# Altium schematic sheet 11-Ice40_FPGA.SchDoc(11-Ice40_FPGA)
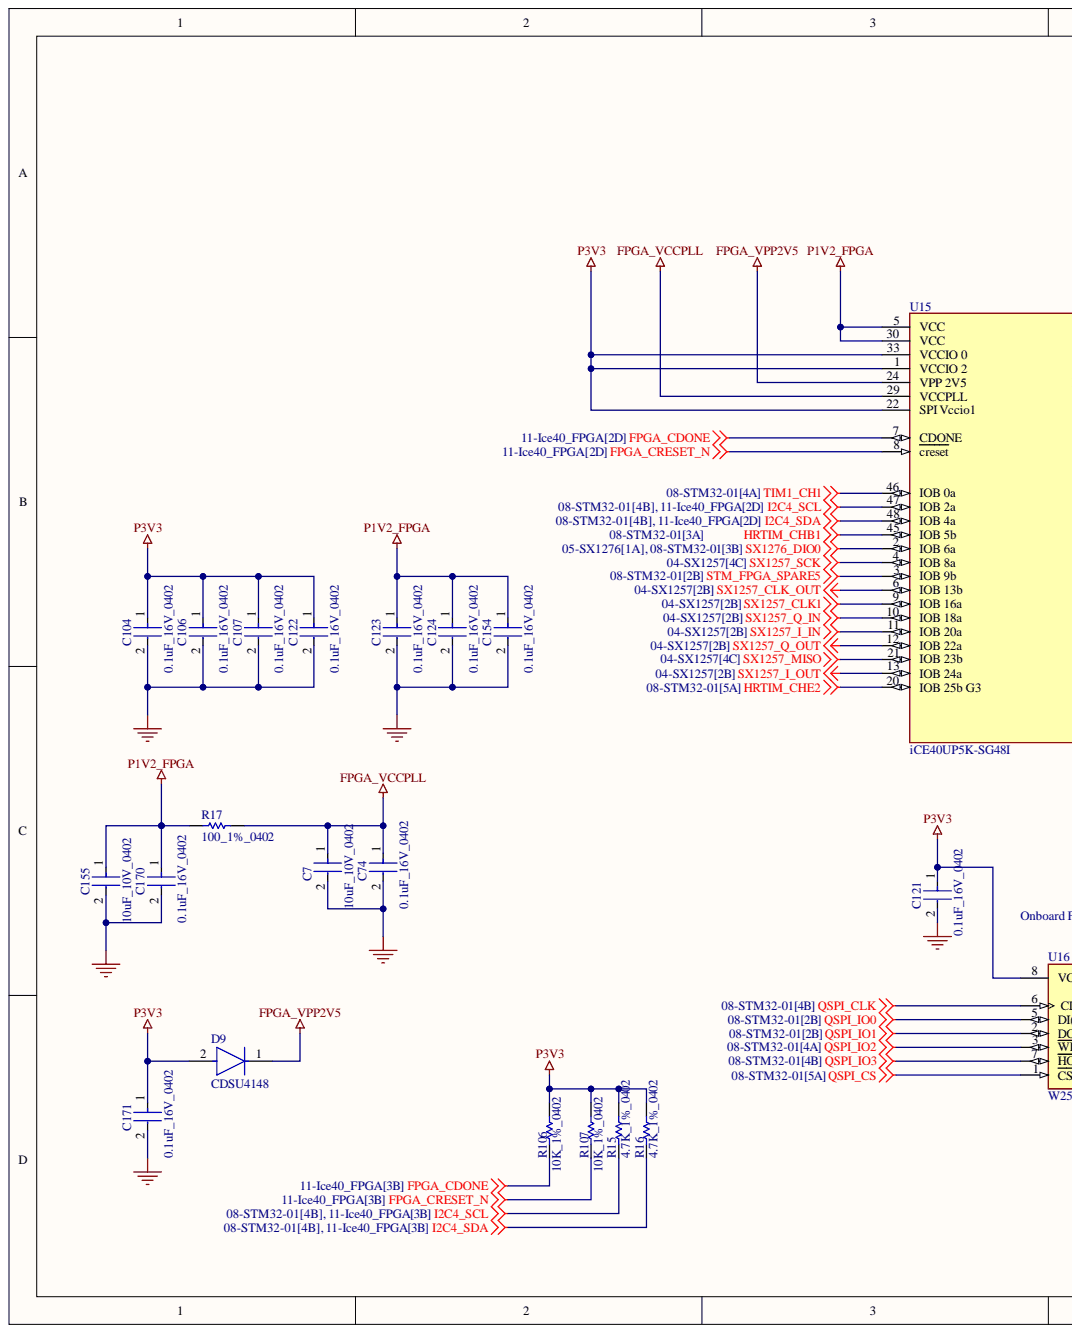
[diagram p0: 11-Ice40_FPGA.SchDoc, left]
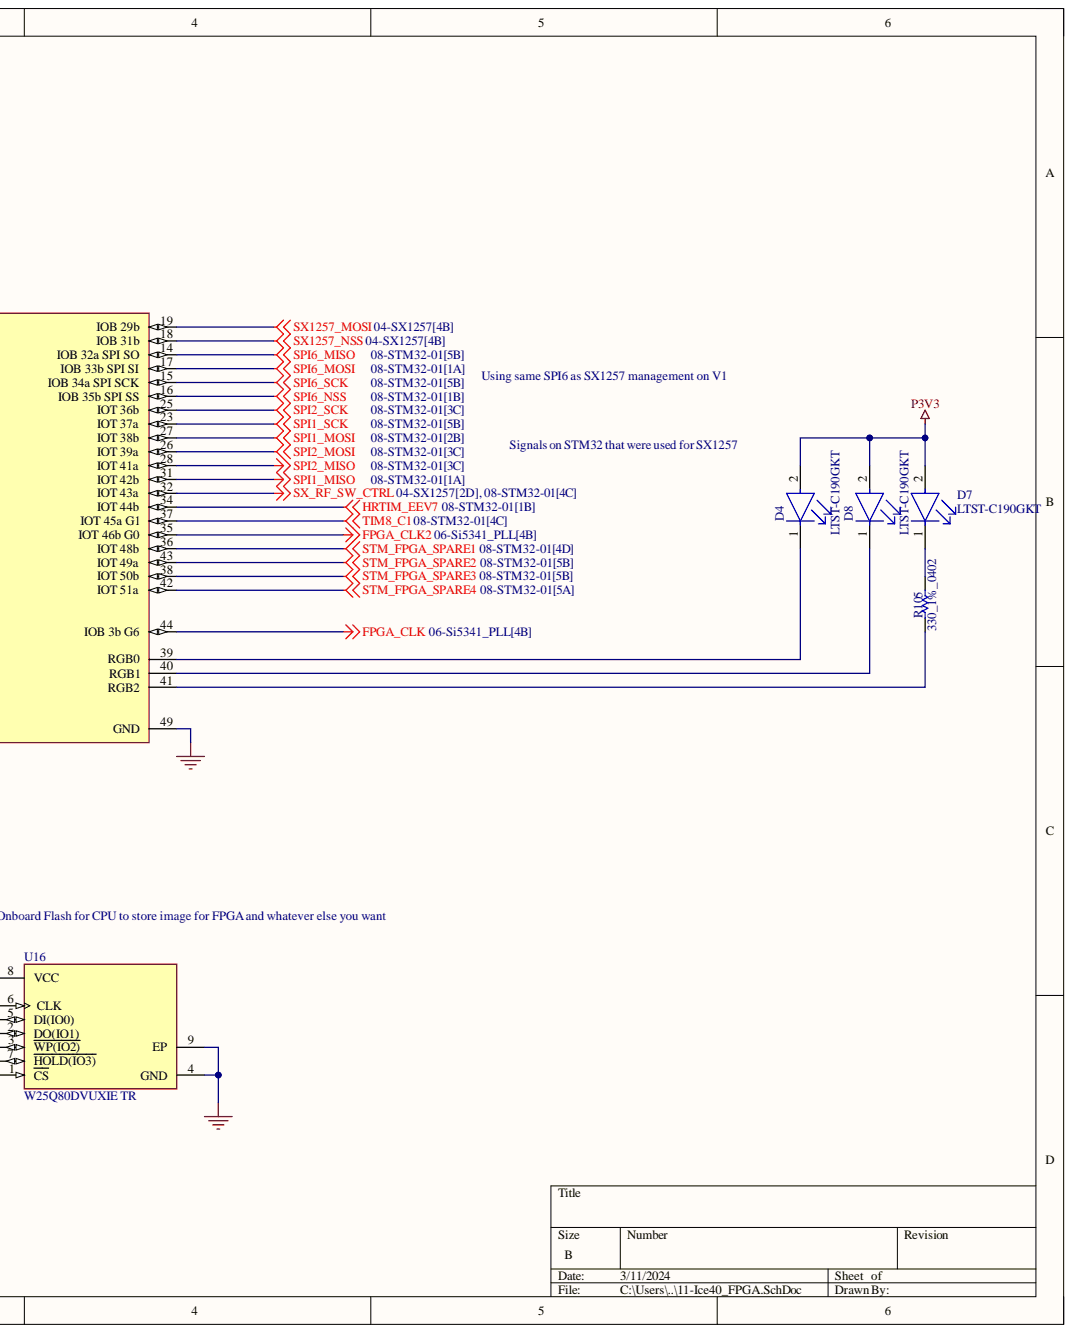
[diagram p1: 11-Ice40_FPGA.SchDoc, right]

## Parts
C7  10uF_10V_0402  [FP-CL05A106MP5NUNC-MFG]
C74  0.1uF_16V_0402  [FP-C0402-BB-MFG]
C104  0.1uF_16V_0402  [FP-C0402-BB-MFG]
C106  0.1uF_16V_0402  [FP-C0402-BB-MFG]
C107  0.1uF_16V_0402  [FP-C0402-BB-MFG]
C121  0.1uF_16V_0402  [FP-C0402-BB-MFG]
C122  0.1uF_16V_0402  [FP-C0402-BB-MFG]
C123  0.1uF_16V_0402  [FP-C0402-BB-MFG]
C124  0.1uF_16V_0402  [FP-C0402-BB-MFG]
C154  0.1uF_16V_0402  [FP-C0402-BB-MFG]
C155  10uF_10V_0402  [FP-CL05A106MP5NUNC-MFG]
C170  0.1uF_16V_0402  [FP-C0402-BB-MFG]
C171  0.1uF_16V_0402  [FP-C0402-BB-MFG]
D4  LTST-C190GKT  [FP-LTST-C190GKT-MFG]
D7  LTST-C190GKT  [FP-LTST-C190GKT-MFG]
D8  LTST-C190GKT  [FP-LTST-C190GKT-MFG]
D9  CDSU4148  [FP-0603-SOD-523F-MFG]
R15  4.7K_1%_0402  [RESC1005X40X25NL10T10]
R16  4.7K_1%_0402  [RESC1005X40X25NL10T10]
R17  100_1%_0402  [RESC1005X40X25LL05T05]
R105  330_1%_0402  [RESC1005X40X25NL10T10]
R106  10K_1%_0402  [RESC1005X40X25NL10T10]
R107  10K_1%_0402  [RESC1005X40X25NL10T10]
U15  iCE40UP5K-SG48I  [FP-QFN-48-IPC_C]
U16  W25Q80DVUXIE TR  [FP-UX-MFG]

## Nets
FPGA_CDONE: R106.1 U15.7(CDONE)
FPGA_CLK: U15.44(IOB_3b_G6)
FPGA_CLK2: U15.35(IOT_46b_G0)
FPGA_CRESET_N: R107.1 U15.8(creset)
FPGA_VCCPLL: C7.1 C74.1 R17.2 U15.29(VCCPLL)
FPGA_VPP2V5: D9.1 U15.24(VPP_2V5)
GND: C7.2 C74.2 C104.2 C106.2 C107.2 C121.2 C122.2 C123.2 C124.2 C154.2 C155.2 C170.2 C171.2 U15.49(GND) U16.4(GND) U16.9(EP)
HRTIM_CHB1: U15.45(IOB_5b)
HRTIM_CHE2: U15.20(IOB_25b_G3)
HRTIM_EEV7: U15.34(IOT_44b)
I2C4_SCL: R15.1 U15.47(IOB_2a)
I2C4_SDA: R16.1 U15.48(IOB_4a)
P1V2_FPGA: C123.1 C124.1 C154.1 C155.1 C170.1 R17.1 U15.5(VCC) U15.30(VCC)
P3V3: C104.1 C106.1 C107.1 C121.1 C122.1 C171.1 D4.2 D7.2 D8.2 D9.2 R15.2 R16.2 R106.2 R107.2 U15.1(VCCIO_2) U15.22(SPI_Vccio1) U15.33(VCCIO_0) U16.8(VCC)
QSPI_CLK: U16.6(CLK)
QSPI_CS: U16.1(CS)
QSPI_IO0: U16.5(DI(IO0))
QSPI_IO1: U16.2(DO(IO1))
QSPI_IO2: U16.3(WP(IO2))
QSPI_IO3: U16.7(HOLD(IO3))
SPI1_MISO: U15.31(IOT_42b)
SPI1_MOSI: U15.27(IOT_38b)
SPI1_SCK: U15.23(IOT_37a)
SPI2_MISO: U15.28(IOT_41a)
SPI2_MOSI: U15.26(IOT_39a)
SPI2_SCK: U15.25(IOT_36b)
SPI6_MISO: U15.14(IOB_32a_SPI_SO)
SPI6_MOSI: U15.17(IOB_33b_SPI_SI)
SPI6_NSS: U15.16(IOB_35b_SPI_SS)
SPI6_SCK: U15.15(IOB_34a_SPI_SCK)
STM_FPGA_SPARE1: U15.36(IOT_48b)
STM_FPGA_SPARE2: U15.43(IOT_49a)
STM_FPGA_SPARE3: U15.38(IOT_50b)
STM_FPGA_SPARE4: U15.42(IOT_51a)
STM_FPGA_SPARE5: U15.3(IOB_9b)
SX1257_CLK1: U15.9(IOB_16a)
SX1257_CLK_OUT: U15.6(IOB_13b)
SX1257_I_IN: U15.11(IOB_20a)
SX1257_I_OUT: U15.13(IOB_24a)
SX1257_MISO: U15.21(IOB_23b)
SX1257_MOSI: U15.19(IOB_29b)
SX1257_NSS: U15.18(IOB_31b)
SX1257_Q_IN: U15.10(IOB_18a)
SX1257_Q_OUT: U15.12(IOB_22a)
SX1257_SCK: U15.4(IOB_8a)
SX1276_DIO0: U15.2(IOB_6a)
SX_RF_SW_CTRL: U15.32(IOT_43a)
TIM1_CH1: U15.46(IOB_0a)
TIM8_C1: U15.37(IOT_45a_G1)
NetD4_1: D4.1 U15.39(RGB0)
NetD7_1: D7.1 R105.2
NetD8_1: D8.1 U15.40(RGB1)
NetR105_1: R105.1 U15.41(RGB2)

## Library data of the parts
C7 C155 = CMP-13271-000002-1: CAP CER 10UF 10V X5R 0402; Manufacturer: Samsung; Manufacturer Part Number: CL05A106MP5NUNC; Material: Ceramic; Temperature Coefficient: 15%; Tolerance: 20%; Automotive: No; Capacitance: 10uF; Category: Cap; Component Kind: Standard; ComponentLink2Description: Datasheet; ComponentLink2URL: http://www.samsungsem.com/kr/support/product-search/mlcc/__icsFiles/afieldfile/2019/05/10/19-CL05A106MP5NUNC.pdf; Device Class L1: Passive Components; Device Class L2: Capacitors; Device Class L3: Ceramic Capacitors; Digikey Description: CAP CER 10UF 10V X5R 0402; Height: 0.7mm; Ipc Land Pattern Name: CAPC10050X50; Lead Free: Yes; Library Name: Altium Content Vault; Octopart: https://octopart.com/cl05a106mp5nunc-samsung-22457395; Package: CL05A106MP5NUNC; RoHS: TRUE; Temperature: -55°C to +85°C; Temperature Characteristic: X5R; Temperature Range High: +85°C; Temperature Range Low: -55°C; Value: 10uF; Voltage Rating: 10V
C74 C104 C106 C107 C121-C124 C154 C170 C171 = CMP-1034-02068-3: CAP CER 0.1UF 16V 5% X7R 0402; Manufacturer: Kemet; Manufacturer Part Number: C0402C104J4RACTU; Capacitance: 0.10 μF; Material: Ceramic; Temperature Coefficient: 15%; Tolerance: 0.05; Value: 0.10 μF; Automotive: No; Category: Cap; Component Kind: Standard; ComponentLink2Description: Datasheet; ComponentLink2URL: https://content.kemet.com/datasheets/KEM_C1002_X7R_SMD.pdf; Device Class L1: Passive Components; Device Class L2: Capacitors; Device Class L3: Ceramic Capacitors; Digikey Description: CAP CER 0.1UF 16V 5% X7R 0402; Height: 0.55mm; Ipc Land Pattern Name: CAPC10050X50; Lead Free: Yes; Library Name: Altium Content Vault; Mouser Description: CAP CER 0.1UF 16V X7R 0402; Octopart: https://octopart.com/c0402c104j4ractu-kemet-12573893; Package: C0402-BB; RoHS: TRUE; Temperature: -55°C to +125°C; Temperature Characteristic: X7R; Temperature Range High: +125°C; Temperature Range Low: -55°C; Voltage Rating: 16 V
D4 D7 D8 = CMP-22017-000005-2: LED GREEN CLEAR CHIP SMD; Dominant Wavelength: 569nm; Forward Current: 30mA; Forward Voltage: 2.1V; Lens Color: Colorless; Luminous Intensity: 6mcd; Number of Elements: 1; Power Dissipation: 100mW; Reverse Voltage: 5V; Test Current: 10mA; Viewing Angle: 130°; Voltage: 2V; Wavelength: 565nm; Case Code (Imperial): 0603; Case Code (Metric): 1608; Case/Package: 0603; Color: Green; Component Kind: Standard; Depth: 0.8mm; Height: 0.8mm; Illumination Color: Green; Lead Free: Lead Free; Length: 1.6mm; Lens Style: Clear; Lens Transparency: Clear; Library Name: Altium Content Vault; Max Operating Temperature: 85°C; Min Operating Temperature: -55°C; Mount: Surface Mount; Number of LEDs: 1; Number of Pins: 2; Package Quantity: 1; Packaging: Tape and Reel; Power Rating: 100mW; Radiation Hardening: No; Width: 0.8mm
D9 = CMP-20228-000007-1: DIODE GEN PURP 75V 150MA 0603; Capacitance: 3pF; Current Rating: 100mA; Max Power Dissipation: 150mW; Max Reverse Leakage Current: 2.5uA; Max Surge Current: 4A; Polarity: Standard; Recovery Time: 4ns; Average Rectified Current: 150mA; Case/Package: SMD/SMT; Component Kind: Standard; Lead Free: Lead Free; Library Name: Altium Content Vault; Max Reverse Voltage (DC): 75V; Mount: Surface Mount; Output Current: 100mA; Package Quantity: 1; Packaging: Tape and Reel; Reverse Recovery Time: 4ns; Voltage Rating (DC): 75V
R15 R16 = CMP-2002-05217-1: Manufacturer: Bourns; Part Number: CR0402-FX-4701GLF; Component Kind: Standard; Library Name: Altium Content Vault
R17 = CMP-2002-00605-3: Chip Resistor, 100 Ohm, +/- 1%, 100 mW, -55 to 155 degC, 0402 (1005 Metric), RoHS, Tape and Reel; Manufacturer: Basic Passives; PartNumber: ERJ-2RKF1000X; Case\/Package: 0402; Power Rating: 100 mW; Resistance: 100 Ohm; Voltage Rating: 50 V; Case Code (Imperial): 0402; Case Code (Metric): 1005; Component Kind: Standard; ComponentLink1Description: Manufacturer URL; ComponentLink1URL: http://www.panasonic.com/; ComponentLink2Description: Datasheet; ComponentLink2URL: http://industrial.panasonic.com/cdbs/www-data/pdf/RDA0000/AOA0000C86.pdf; DatasheetVersion: 04/2015; Height: 0.35 mm; Length: 1 mm; Library Name: Altium Content Vault; Max Operating Temperature: 155 degC; Min Operating Temperature: -55 degC; Mount: Surface Mount; Mounting Technology: Surface Mount; Package Quantity: 10000; PackageDescription: 2-Pin Surface Mount Device, Body 1 x 0.5 mm; PackageReference: 0402; Packaging: Tape and Reel; Pins: 2; Radiation Hardening: No; REACH SVHC: Yes; RoHSCompliant: true; Tolerance: 1%; Width: 0.5 mm
R105 = CMP-2002-05853-1: Manufacturer: Panasonic; Part Number: ERA-2AED331X; Component Kind: Standard; Library Name: Altium Content Vault
R106 R107 = CMP-2002-04694-1: Manufacturer: Bourns; Part Number: CR0402-FX-1002GLF; Component Kind: Standard; Library Name: Altium Content Vault
U15 = CMP-17932-000001-1: ICE40-ULTRAPLUS, 5280 LUTS, 1.2V; Manufacturer: Lattice; Frequency: 48MHz; Max Junction Temp: +100°C; Max Supply Voltage: 3.46V; Min Supply Voltage: 1.14V; Nominal Supply Current: 2.5-12mA; Number Of I/Os: 39; Number Of Logic Blocks: 660; Number Of Logic Elements Cells: 5280; Propagation Delay: 9ns; Ram Size: 1024Kbits; Standoff Height: 0mm; Automotive: No; Category: IC; Component Kind: Standard; ComponentLink2Description: Datasheet; ComponentLink2URL: http://www.latticesemi.com/view_document?document_id=51968; Device Class L1: Integrated Circuits (ICs); Device Class L2: Embedded Processors and Controllers; Device Class L3: FPGAs - Field Programmable Gate Arrays; Digikey Description: ICE40-ULTRAPLUS, 5280 LUTS, 1.2V; Footprint Url: http://www.latticesemi.com/view_document?document_id=213; Height: 1mm; Ipc Land Pattern Name: QFN50P700X700X90-48; Lead Free: Yes; Library Name: Altium Content Vault; Manufacturer Part Number: iCE40UP5K-SG48I; Octopart: https://octopart.com/ice40up5k-sg48i-lattice+semiconductor-87369311; Package: QFN-48; RoHS: TRUE; Temperature: -40°C to +100°C; Temperature Range High: +100°C; Temperature Range Low: -40°C
U16 = CMP-78876-000004-1: IC FLASH 8M SPI 104MHZ 8USON; Memory Size: 8Mb; Memory Type: Non-Volatile; Component Kind: Standard; Frequency: 104MHz; Library Name: Altium Content Vault; Max Operating Temperature: 85°C; Min Operating Temperature: -40°C; Mount: Surface Mount; Packaging: Tape and Reel
